# Altium schematic sheet Power Management.SchDoc("Power Management")
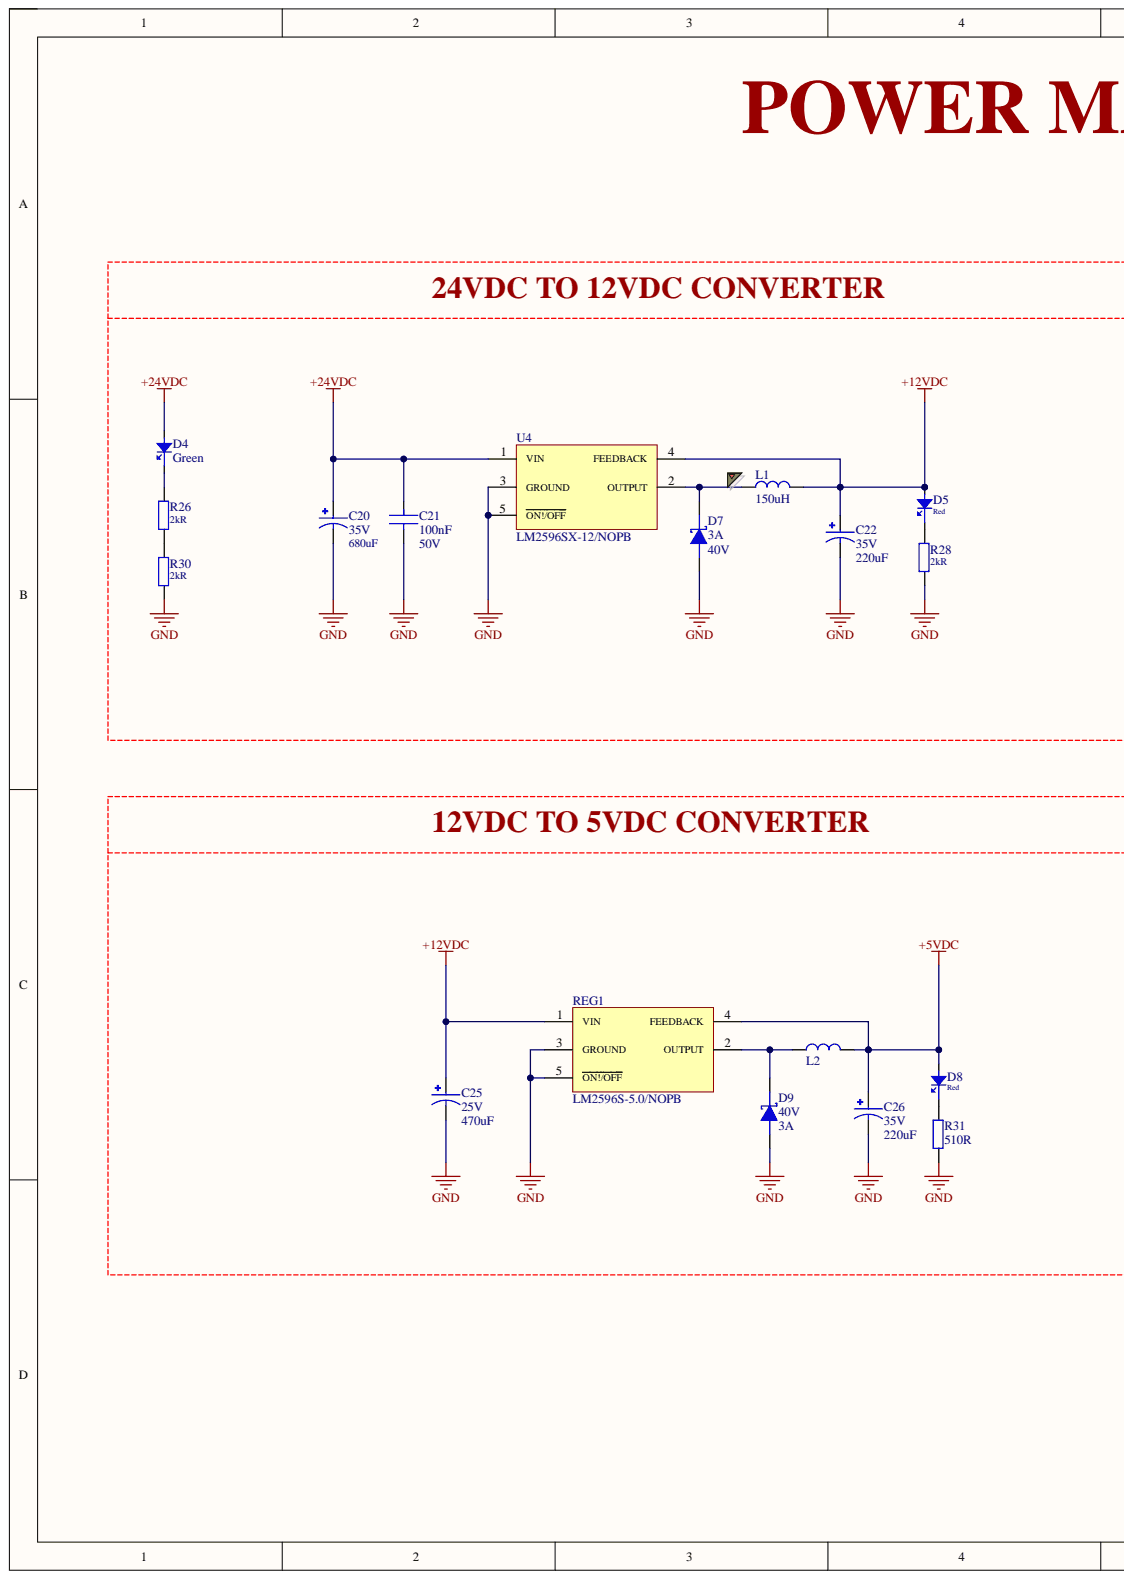
[diagram p0: Power Management.SchDoc, left]
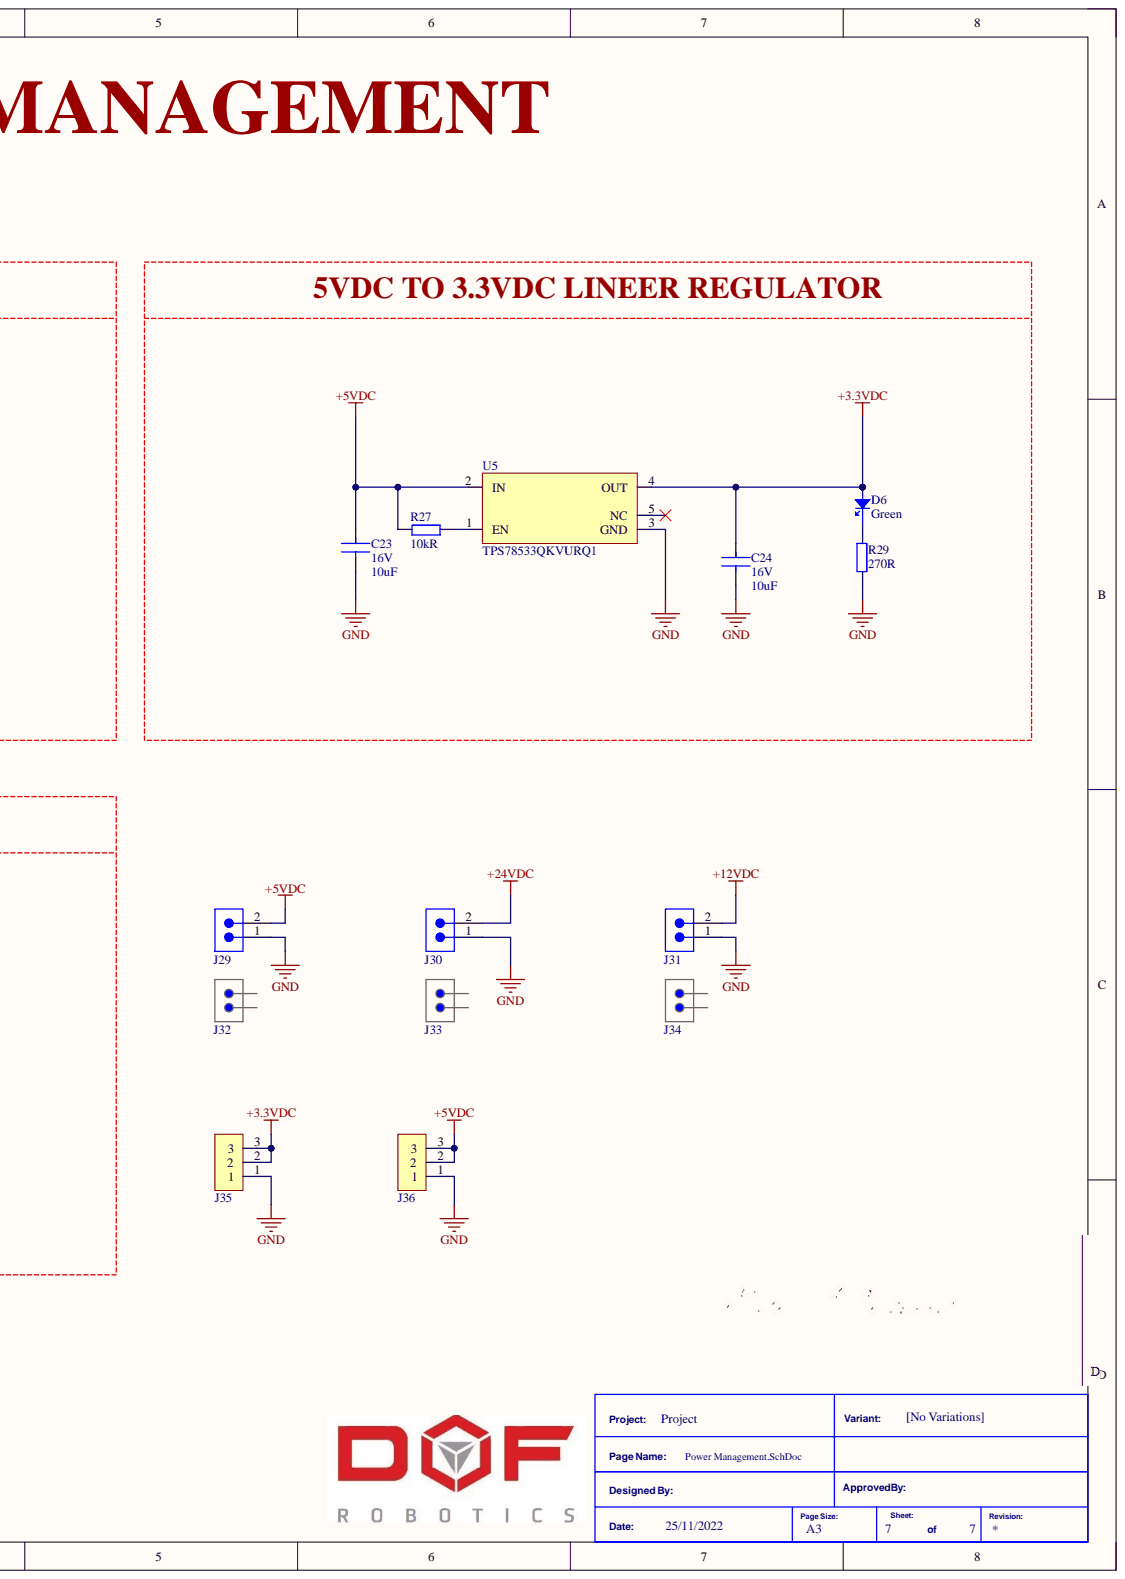
[diagram p1: Power Management.SchDoc, right]

## Parts
C20  Capacitance: 680uF  Voltage: 35V  [CAP ALUM RAD 10MM]  (hidden comment: EEU-FR1V681)
C21  Capacitance: 100nF  Voltage Rating (DC): 50V  [CAP CER 0603]  (hidden comment: C0603C104K5RAC7082)
C22  Capacitance: 220uF  Voltage: 35V  [CAP ALUM SMD 10X10X12]  (hidden comment: MAL214699004E3)
C23  Capacitance: 10uF  Voltage: 16V  [CAP CER 0805]  (hidden comment: C0805C106K4PACTU)
C24  Capacitance: 10uF  Voltage: 16V  [CAP CER 0805]  (hidden comment: C0805C106K4PACTU)
C25  Capacitance: 470uF  Voltage Rating: 25V  [CAP ALUM SMD 8.3X8.3]  (hidden comment: EEEFT1E471GP)
C26  Capacitance: 220uF  Voltage: 35V  [CAP ALUM SMD 10X10X12]  (hidden comment: MAL214699004E3)
D4  Color: Green  [LED GREEN 0805]  (hidden comment: CMD17-21VGD/TR8)
D5  Color: Red  [LED RED 0603]  (hidden comment: 150060RS75000)
D6  Color: Green  [LED GREEN 0805]  (hidden comment: CMD17-21VGD/TR8)
D7  Average Rectified Current: 3A  Max Reverse Voltage (DC): 40V  [DO-214AC]  (hidden comment: ACDBA340-HF)
D8  Color: Red  [LED RED 0603]  (hidden comment: 150060RS75000)
D9  Average Rectified Current: 3A  Max Reverse Voltage (DC): 40V  [DO-214AC]  (hidden comment: ACDBA340-HF)
J29  691313710002  [CONN ML 1X2 WURTH 691313710002]  (value not drawn)
J30  691313710002  [CONN ML 1X2 WURTH 691313710002]  (value not drawn)
J31  691313710002  [CONN ML 1X2 WURTH 691313710002]  (value not drawn)
J32  691352710002  [CONN FML 1X2 WURTH 691352710002]  (value not drawn)
J33  691352710002  [CONN FML 1X2 WURTH 691352710002]  (value not drawn)
J34  691352710002  [CONN FML 1X2 WURTH 691352710002]  (value not drawn)
J35  61300311121  [HDR_ML_1X3]  (value not drawn)
J36  61300311121  [HDR_ML_1X3]  (value not drawn)
L1  Inductance: 150uH  [IND WURTH 12.3X12.3]  (hidden comment: 744771215)
L2  7447706680  [IND WURTH 12.3X12.3]  (value not drawn)
R26  Resistance: 2kR  [RES 0603]  (hidden comment: RC0603FR-072KL)
R27  Resistance: 10kR  [RES 0805]  (hidden comment: RNCP0805FTD10K0)
R28  Resistance: 2kR  [RES 0603]  (hidden comment: RC0603FR-072KL)
R29  Resistance: 270R  [RES 0805]  (hidden comment: CRGCQ0805F270R)
R30  Resistance: 2kR  [RES 0603]  (hidden comment: RC0603FR-072KL)
R31  Resistance: 510R  [RES 0603]  (hidden comment: ESR03EZPJ511)
REG1  LM2596S-5.0/NOPB  [LM2596S-5.0/NOPB]
U4  LM2596SX-12/NOPB  [LM2596S-5.0/NOPB]
U5  TPS78533QKVURQ1  [TO-252-5]

## Nets
+3.3VDC: C24.2 D6.2 J35.2 J35.3 U5.4(OUT)
+5VDC: C23.2 C26.1 D8.2 J29.2 J36.2 J36.3 L2.2 R27.2 REG1.4(FEEDBACK) U5.2(IN)
+12VDC: C22.1 C25.1 D5.2 J31.2 L1.2 REG1.1(VIN) U4.4(FEEDBACK)
+24VDC: C20.1 C21.2 D4.2 J30.2 U4.1(VIN)
GND: C20.2 C21.1 C22.2 C23.1 C24.1 C25.2 C26.2 D7.A D9.A J29.1 J30.1 J31.1 J35.1 J36.1 R28.1 R29.1 R30.1 R31.1 REG1.3(GROUND) REG1.5(ON!/OFF) U4.3(GROUND) U4.5(ON!/OFF) U5.3(GND)
NetD4_1: D4.1 R26.2
NetD5_1: D5.1 R28.2
NetD6_1: D6.1 R29.2
NetD7_C: D7.C L1.1 U4.2(OUTPUT)
NetD8_1: D8.1 R31.2
NetD9_C: D9.C L2.1 REG1.2(OUTPUT)
NetR26_1: R26.1 R30.2
NetR27_1: R27.1 U5.1(EN)

Not connected: U5 5(NC)

## Library data of the parts
C20 = CAP ALUM 680UF 35V RADIAL: CAP ALUM 680UF 20% 35V RADIAL; Manufacturer: Panasonic; ESR (Equivalent Series Resistance): 20mR; Lead Diameter: 0.6mm; Lead/Base Style: Straight; Leakage Current: 3uA; Life (Hours): 10000Hour; Ripple Current: 2.18A; Case/Package: Radial; Category: Aluminum Electrolytic Capacitors; Component Kind: Standard; ComponentLink1Description: https://datasheet.ciiva.com/11780/0900766b80e4e9bf-11780986.pdf?src-supplier=RSComponents; ComponentLink1URL: https://datasheet.ciiva.com/11780/0900766b80e4e9bf-11780986.pdf?src-supplier=RSComponents; ComponentLink2Description: https://datasheet.ciiva.com/11677/aba0000ce132-11677717.pdf?src-supplier=Avnet; ComponentLink2URL: https://datasheet.ciiva.com/11677/aba0000ce132-11677717.pdf?src-supplier=Avnet; ComponentLink3Description: https://datasheet.ciiva.com/14602/70257620-14602587.pdf?src-supplier=Allied+Electronics; ComponentLink3URL: https://datasheet.ciiva.com/14602/70257620-14602587.pdf?src-supplier=Allied+Electronics; ComponentLink4Description: https://datasheet.ciiva.com/30145/9aba0000ce132-30145388.pdf?src-supplier=Onlinecomponents.com; ComponentLink4URL: https://datasheet.ciiva.com/30145/9aba0000ce132-30145388.pdf?src-supplier=Onlinecomponents.com; ComponentLink5Description: https://datasheet.ciiva.com/17531/aba0000ce132-17531901.pdf?src-supplier=Avnet+Europe; ComponentLink5URL: https://datasheet.ciiva.com/17531/aba0000ce132-17531901.pdf?src-supplier=Avnet+Europe; Construction: RADIAL; Contact Plating: Tin; Diameter: 10mm; Height: 20mm; Height - Seated (Max): 0.866inch; Impedance: 20mR; Lead Pitch: 5mm; Length: 20mm; Manufacturer Part Number: EEU-FR1V681; Max Operating Temperature: 105°C; Min Operating Temperature: -40°C; Mount: Through Hole; Number of Pins: 2; Package Quantity: 200; Packaging: Bulk; Pricing 1: 1=1.09000, 10=0.82700, 50=0.68680, 100=0.59140, 500=0.45788, 1000=0.39429, 2500=0.36885, 5000=0.34977 (USD); Radiation Hardening: No; REACH SVHC: Unknown; Ripple Current (AC): 2.18A; RoHS: Yes; RoHS Compliant: Yes; Series: FR; Stock 1: 13043; Supplier 1: Digi-Key; Supplier Part Number 1: P14514-ND; Termination: Radial; Tolerance: 20%; Voltage Rating: 35V; Voltage Rating (DC): 35V; Weight: 2.5; Library Name: LibEnes.SchLib
C21 = CAP CER 0.1UF 50V X7R 0603: Cap Cer 0.1uF 50V 0603 10%; Manufacturer: KEMET; DesignedBy: ENES MERCAN; Dielectric: X7R; Dielectric Material: Ceramic; Case/Package: 0603; Category: Ceramic Capacitors; Component Kind: Standard; Library Name: LibEnes.SchLib; Manufacturer Part Number: C0603C104K5RAC7082; Pricing 1: 1=0.12000, 10=0.08900, 50=0.04840, 100=0.03940, 500=0.02818, 1000=0.02214, 2500=0.02012, 5000=0.01852 (USD); RoHS: Yes; RoHS Compliant: Yes; Stock 1: 44787; Supplier 1: Digi-Key; Supplier Part Number 1: 399-C0603C104K5RAC7082CT-ND; Tolerance: 10%; Weight: 0.063
C22 C26 = CAP ALUM 220UF 35V SMD 1012: 220uF 35V ALUM Electrolytic Cap 1012; 146 CTI Series 1500 h; 2000 h; 200000 h; Manufacturer: Vishay BCcomponents; Impedance: 97mR; Lead Diameter: 1mm; Leakage Current: 77uA; Life (Hours): 2000Hour; Polarity: Polar; Ripple Current: 900mA; Tolerance: 20%; Case/Package: Radial; Category: Aluminum Electrolytic Capacitors; Component Kind: Standard; ComponentLink1Description: https://datasheet.ciiva.com/99/146cti-99688.pdf?src-supplier=Digi-Key; ComponentLink1URL: https://datasheet.ciiva.com/99/146cti-99688.pdf?src-supplier=Digi-Key; ComponentLink2Description: https://datasheet.ciiva.com/99/146cti-99689.pdf?src-supplier=Digi-Key; ComponentLink2URL: https://datasheet.ciiva.com/99/146cti-99689.pdf?src-supplier=Digi-Key; ComponentLink3Description: https://datasheet.ciiva.com/11777/0900766b81003e87-11777120.pdf?src-supplier=RSComponents; ComponentLink3URL: https://datasheet.ciiva.com/11777/0900766b81003e87-11777120.pdf?src-supplier=RSComponents; ComponentLink4Description: https://datasheet.ciiva.com/99/146cti-99690.pdf?src-supplier=Digi-Key; ComponentLink4URL: https://datasheet.ciiva.com/99/146cti-99690.pdf?src-supplier=Digi-Key; ComponentLink5Description: https://datasheet.ciiva.com/5360/146cti-247382-5360191.pdf?src-supplier=Mouser; ComponentLink5URL: https://datasheet.ciiva.com/5360/146cti-247382-5360191.pdf?src-supplier=Mouser; ComponentLink6Description: https://datasheet.ciiva.com/11697/146cti-11697674.pdf?src-supplier=Avnet; ComponentLink6URL: https://datasheet.ciiva.com/11697/146cti-11697674.pdf?src-supplier=Avnet; Construction: Cylindrical; Diameter: 10mm; Height: 12mm; Height - Seated (Max): 0.472inch; Lead Free: Lead Free; Lead Pitch: 3.5mm; Manufacturer Part Number: MAL214699004E3; Max Operating Temperature: 125°C; Min Operating Temperature: -55°C; Mount: Surface Mount; Package Quantity: 250; Packaging: Tape and Reel; Pricing 1: 1=2.65000, 10=2.14700, 50=1.87400, 100=1.63980 (USD); Radiation Hardening: No; RoHS: Yes; RoHS Compliant: Yes; Series: 146 CTI; Stock 1: 4735; Supplier 1: Digi-Key; Supplier Part Number 1: 4427PHCT-ND; Termination: SMD/SMT; Voltage Rating: 35V; Voltage Rating (DC): 35V; Width: 10mm; Library Name: LibEnes.SchLib
C23 C24 = CAP CER 10UF 16V X5R 0805 - C0805C106K4PACTU: CAP CER 10UF 16V X5R 0805; Manufacturer: KEMET; Dielectric Material: Ceramic; Dissipation Factor: 5%; Tolerance: 10%; Case Code (Imperial): 0805; Case Code (Metric): 2012; Case/Package: 0805; Category: Ceramic Capacitors; Component Kind: Standard; ComponentLink1Description: https://datasheet.ciiva.com/7842/1732713-7842196.pdf?src-supplier=Element14; ComponentLink1URL: https://datasheet.ciiva.com/7842/1732713-7842196.pdf?src-supplier=Element14; ComponentLink2Description: https://datasheet.ciiva.com/28682/1831760-28682305.pdf?src-supplier=Element14; ComponentLink2URL: https://datasheet.ciiva.com/28682/1831760-28682305.pdf?src-supplier=Element14; ComponentLink3Description: https://datasheet.ciiva.com/11787/0900766b8116eb59-11787314.pdf?src-supplier=RSComponents; ComponentLink3URL: https://datasheet.ciiva.com/11787/0900766b8116eb59-11787314.pdf?src-supplier=RSComponents; ComponentLink4Description: https://datasheet.ciiva.com/7842/1732713-7842197.pdf?src-supplier=Element14; ComponentLink4URL: https://datasheet.ciiva.com/7842/1732713-7842197.pdf?src-supplier=Element14; ComponentLink5Description: https://datasheet.ciiva.com/26847/getdatasheetpartid-110990-26847815.pdf?src-supplier=Verical; ComponentLink5URL: https://datasheet.ciiva.com/26847/getdatasheetpartid-110990-26847815.pdf?src-supplier=Verical; Construction: Flat; Contact Plating: Tin,Matte; Depth: 1.25mm; Dielectric: X5R; Height: 1.25mm; Lead Free: Lead Free; Length: 2mm; Manufacturer Part Number: C0805C106K4PACTU; Max Operating Temperature: 85°C; Min Operating Temperature: -55°C; Mount: Surface Mount; Number of Pins: 2; Package Quantity: 1; Packaging: Tape and Reel; Pricing 1: 1=0.17000, 10=0.11500, 50=0.06900, 100=0.05830, 500=0.03992, 1000=0.03531 (USD); Radiation Hardening: No; REACH SVHC: No SVHC; RoHS: Yes; RoHS Compliant: Yes; Series: C; Stock 1: 1733841; Supplier 1: Digi-Key; Supplier Part Number 1: 399-C0805C106K4PAC7800CT-ND; Termination: SMD/SMT; Thickness: 0.055inch; Voltage Rating: 16V; Voltage Rating (DC): 16V; Width: 0.049inch; Library Name: LibEnes.SchLib
C25 = CAP ALUM 470UF 25V FT 8X10: Cap Aluminum Lytic 470uF 25V 20% (8 X 10.2mm) SMD 0.08 Ohm 850mA 5000h 105C Automotive T/R; Manufacturer: Panasonic; ESR (Equivalent Series Resistance): 80mR; Life (Hours): 5000Hour; Polarity: Polar; Ripple Current: 552.5mA; Case/Package: Radial; Category: Aluminum Electrolytic Capacitors; Component Kind: Standard; ComponentLink1Description: http://www.farnell.com/datasheets/2813661.pdf?src-supplier=Farnell; ComponentLink1URL: http://www.farnell.com/datasheets/2813661.pdf?src-supplier=Farnell; ComponentLink2Description: http://www.farnell.com/datasheets/2079855.pdf?src-supplier=Element14; ComponentLink2URL: http://www.farnell.com/datasheets/2079855.pdf?src-supplier=Element14; ComponentLink3Description: https://industrial.panasonic.com/cdbs/www-data/pdf/RDE0000/DME0000COL53.pdf?src-supplier=Digi-Key; ComponentLink3URL: https://industrial.panasonic.com/cdbs/www-data/pdf/RDE0000/DME0000COL53.pdf?src-supplier=Digi-Key; ComponentLink4Description: https://industrial.panasonic.com/cdbs/www-data/pdf/RDE0000/DME0000COL41.pdf?src-supplier=Digi-Key; ComponentLink4URL: https://industrial.panasonic.com/cdbs/www-data/pdf/RDE0000/DME0000COL41.pdf?src-supplier=Digi-Key; Diameter: 8mm; Height: 10.2mm; Height - Seated (Max): 0.413inch; Manufacturer Part Number: EEEFT1E471GP; Max Operating Temperature: 105°C; Min Operating Temperature: -55°C; Mount: Surface Mount; Packaging: Cut Tape; Pricing 1: 1=1.33000, 10=1.01300, 50=0.84180, 100=0.72480 (USD); REACH SVHC: No SVHC; Ripple Current (AC): 850mA; RoHS: Yes; RoHS Compliant: Yes; Stock 1: 27228; Supplier 1: Digi-Key; Supplier Part Number 1: PCE5116CT-ND; Tolerance: 20%; Voltage Rating (DC): 25V; Library Name: LibEnes.SchLib
D4 D6 = LED GREEN 0805: LED, Green, SMD 0805, 7.0 mcd, Green Diffused Lens; Manufacturer: VCC CHML; Forward Current: 20mA; Forward Voltage: 2.1V; Lens Style: Rectangular,Diffused,Tinted,Colored; Luminous Intensity: 7mcd; Number of Elements: 1; Power Dissipation: 105mW; Reverse Voltage: 5V; Viewing Angle: 140°; Wavelength: 570nm; Case Code (Imperial): 0805; Case Code (Metric): 2012; Case/Package: 0805; Category: LEDs; Component Kind: Standard; ComponentLink1Description: https://datasheet.ciiva.com/46391/719069-46391062.pdf?src-supplier=Element14; ComponentLink1URL: https://datasheet.ciiva.com/46391/719069-46391062.pdf?src-supplier=Element14; ComponentLink2Description: https://datasheet.ciiva.com/16437/cmd17-21seriessmtleds0805packagesize-16437018.pdf?src-supplier=Future+Electronics; ComponentLink2URL: https://datasheet.ciiva.com/16437/cmd17-21seriessmtleds0805packagesize-16437018.pdf?src-supplier=Future+Electronics; Depth: 1.25mm; Height: 1.1mm; Illumination Color: Green; Lead Free: Lead Free; Length: 2mm; Library Name: My_SCH_Lib.SchLib; Manufacturer Part Number: CMD17-21VGD/TR8; Max Operating Temperature: 85°C; Min Operating Temperature: -40°C; Mount: Surface Mount; Number of LEDs: 1; Number of Pins: 2; Package Quantity: 3000; Packaging: Tape and Reel; Power Rating: 105mW; Pricing 1: 1=0.69000, 10=0.45100, 100=0.29850, 1000=0.23051 (USD); REACH SVHC: Unknown; Reverse Voltage (DC): 5V; RoHS: Yes; Stock 1: 77654; Supplier 1: Digi-Key; Supplier Part Number 1: L62505DKR-ND; Test Current: 20mA; Width: 1.25mm
D5 D8 = LED RED 0603: WURTH ELEKTRONIK - 150060RS75000 - LED, Red, 625 nm, 2 V, 30 mA, 250 mcd RoHS Compliant: Yes; Manufacturer: Wurth Electronics; Dominant Wavelength: 625nm; Forward Current: 30mA; Forward Voltage: 2V; Lens Style: Clear,Rectangular; Luminous Intensity: 250mcd; Test Current: 20mA; Viewing Angle: 140°; Case Code (Imperial): 0603; Case Code (Metric): 1608; Case/Package: 0603; Category: LEDs; Component Kind: Standard; ComponentLink1Description: https://datasheet.ciiva.com/29563/150060rs75000-29563040.pdf?src-supplier=Digi-Key; ComponentLink1URL: https://datasheet.ciiva.com/29563/150060rs75000-29563040.pdf?src-supplier=Digi-Key; ComponentLink2Description: https://datasheet.ciiva.com/29279/759428-da-01-en-chipled-0603-w-kl-rot-150060rs75000-29279196.pdf?src-supplier=Conrad; ComponentLink2URL: https://datasheet.ciiva.com/29279/759428-da-01-en-chipled-0603-w-kl-rot-150060rs75000-29279196.pdf?src-supplier=Conrad; Height: 0.7mm; Length: 1.6mm; Manufacturer Part Number: 150060RS75000; Max Operating Temperature: 85°C; Min Operating Temperature: -40°C; Mount: Surface Mount; Package Quantity: 1; Packaging: Cut Tape; Pricing 1: 1=0.14000, 50=0.13300, 100=0.12000, 500=0.11400, 1000=0.09900 (USD); REACH SVHC: Unknown; RoHS: Yes; RoHS Compliant: Yes; Stock 1: 253591; Supplier 1: Digi-Key; Supplier Part Number 1: 732-4978-1-ND; Wavelength: 625nm; Weight: 0.0025; Width: 0.8mm; Library Name: LibEnes.SchLib
D7 D9 = SCHOTTKY 40V 3A ACDBA340-HF: Rectifier Diode Schottky 40V 3A Automotive 2-Pin SMA T/R; Manufacturer: Comchip; Case/Package: DO-214AC; Component Kind: Standard; ComponentLink1Description: http://www.comchiptech.com/admin/files/product/ACDBA340-HF%20RevA772473.pdf?src-supplier=Digi-Key; ComponentLink1URL: http://www.comchiptech.com/admin/files/product/ACDBA340-HF%20RevA772473.pdf?src-supplier=Digi-Key; Manufacturer Part Number: ACDBA340-HF; Mount: Surface Mount; Packaging: Tape and Reel; Pricing 1: 1=0.44000, 10=0.35700, 100=0.24320, 500=0.18240, 1000=0.13680, 2000=0.12540 (USD); RoHS: Yes; RoHS Compliant: Yes; Stock 1: 128598; Supplier 1: Digi-Key; Supplier Part Number 1: 641-1877-1-ND; Library Name: LibEnes.SchLib
J29-J31 = CONN ML 1X2 WURTH 691313710002: 5mm Pitch Right Angle Pluggable Term Block; PCB Header; Through Hole; 2 Way  (Mate to 691352710002); Manufacturer: Wurth Electronics; Connector Type: PCB,Terminal Block; Current: 20A; Number of Contacts: 2; Number of Levels: 1; Pitch: 0.197inch; Voltage: 300V; Category: Terminal Blocks; Color: Green; Component Kind: Standard; ComponentLink1Description: https://datasheet.ciiva.com/795/6913137100xx-795778.pdf?src-supplier=Digi-Key; ComponentLink1URL: https://datasheet.ciiva.com/795/6913137100xx-795778.pdf?src-supplier=Digi-Key; ComponentLink2Description: https://datasheet.ciiva.com/8043/1442229-8043531.pdf?src-supplier=Element14; ComponentLink2URL: https://datasheet.ciiva.com/8043/1442229-8043531.pdf?src-supplier=Element14; ComponentLink3Description: https://datasheet.ciiva.com/48692/001088691-da-01-en-wr-tbl-terminal-block3137-691313710002-48692902.pdf?src-supplier=Conrad; ComponentLink3URL: https://datasheet.ciiva.com/48692/001088691-da-01-en-wr-tbl-terminal-block3137-691313710002-48692902.pdf?src-supplier=Conrad; Contact Material: Brass; Height: 12mm; Length: 12.5mm; Manufacturer Part Number: 691313710002; Mount: Through Hole; Number of Positions Per Level: 2; Number of Rows: 1; Package Quantity: 600; Packaging: Bulk; Pricing 1: 1=0.44000, 10=0.39400, 50=0.34000, 100=0.30500, 250=0.28600, 600=0.26900 (USD); REACH SVHC: Unknown; RoHS: Yes; RoHS Compliant: Yes; Series: WR-TBL Series 3137; Stock 1: 2898; Supplier 1: Digi-Key; Supplier Part Number 1: 732-2799-ND; Termination: Solder; Width: 12mm; Library Name: LibEnes.SchLib
J32-J34 = CONN FML 1X2 WURTH 691352710002: Conn Terminal Block F 2 POS 5mm Screw RA Cable Mount 20A Box    (Mate to 691313710002); Manufacturer: Wurth Electronics; Current Rating: 12A; Number of Contacts: 2; Number of Levels: 1; Pitch: 0.197inch; Stripping Length: -7mm; Voltage: 300V; Wire Gauge (Max): 24AWG; Wire Gauge (Min): 12AWG; Category: Terminal Blocks; Color: Green; Component Kind: Standard; ComponentLink1Description: https://datasheet.ciiva.com/7992/1442233-7992379.pdf?src-supplier=Element14; ComponentLink1URL: https://datasheet.ciiva.com/7992/1442233-7992379.pdf?src-supplier=Element14; ComponentLink2Description: https://datasheet.ciiva.com/796/6913527100xx-796063.pdf?src-supplier=Digi-Key; ComponentLink2URL: https://datasheet.ciiva.com/796/6913527100xx-796063.pdf?src-supplier=Digi-Key; Contact Material: Brass; Current: 20A; Lead Free: Lead Free; Length: 18.2mm; Manufacturer Part Number: 691352710002; Mount: Free Hanging; Number of Positions Per Level: 2; Package Quantity: 350; Packaging: Bulk; REACH SVHC: Unknown; RoHS: Yes; RoHS Compliant: Yes; Series: WR-TBL Series 3527; Stock 1: 2782; Supplier 1: Digi-Key; Supplier Part Number 1: 732-2775-ND; Supplier Unit Price 1: 1=1.32000, 10=1.18200, 50=1.02200, 100=0.91400, 350=0.85900, 700=0.80500 (USD); Termination: Screw; Voltage Rating: 300V; Width: 10mm; Wire/Cable Gauge: 24AWG; Library Name: LibEnes.SchLib
J35 J36 = HDR ML 1X3 WURTH 61300311121: CONN HEADER 3 POS 2.54; Manufacturer: Wurth Electronics; Connector Type: Male Pin; Gender: Male; Number of Contacts: 3; Pitch: 0.1inch; Category: Connectors; Color: Black; Component Kind: Standard; ComponentLink1Description: http://www.farnell.com/cad/1737268.pdf?src-supplier=Element14; ComponentLink1URL: http://www.farnell.com/cad/1737268.pdf?src-supplier=Element14; ComponentLink2Description: https://katalog.we-online.de/em/datasheet/6130xx11121.pdf?src-supplier=Digi-Key; ComponentLink2URL: https://katalog.we-online.de/em/datasheet/6130xx11121.pdf?src-supplier=Digi-Key; Contact Material: Copper; Contact Mating Length: 0.236inch; Contact Plating: Gold; Lead Free: Lead Free; Manufacturer Part Number: 61300311121; Mount: Through Hole; Number of Positions: 3; Number of Rows: 1; Packaging: Bulk; Pricing 1: 1=0.13000, 10=0.11300, 25=0.09400, 50=0.07900, 100=0.07100, 1000=0.06600 (USD); REACH SVHC: Unknown; RoHS: Yes; RoHS Compliant: Yes; Stock 1: 76429; Supplier 1: Digi-Key; Supplier Part Number 1: 732-5316-ND; Termination: Solder; Library Name: LibEnes.SchLib
L1 = IND 150UH 1.2A 20%: FIXED IND 150UH 1.21A 230MOHM SM  Inductors, Fixed, WE-PD 1260 150uH 1.21A 0.23Ohm; Manufacturer: Wurth Electronics; Core Material: Ferrite; DC Resistance (DCR): 230mR; Inductance Tolerance: 20%; Saturation Current: 1.43A; Self Resonant Frequency: 4.4MHz; Shielding: Shielded; Test Frequency: 1kHz; Case/Package: Nonstandard; Category: Fixed Inductors; Component Kind: Standard; ComponentLink1Description: https://datasheet.ciiva.com/13319/744771215-63141-13319506.pdf?src-supplier=Mouser; ComponentLink1URL: https://datasheet.ciiva.com/13319/744771215-63141-13319506.pdf?src-supplier=Mouser; ComponentLink2Description: https://datasheet.ciiva.com/6696/744771215-6696200.pdf?src-supplier=Digi-Key; ComponentLink2URL: https://datasheet.ciiva.com/6696/744771215-6696200.pdf?src-supplier=Digi-Key; ComponentLink3Description: https://datasheet.ciiva.com/30489/0900766b81281bac-30489276.pdf?src-supplier=RSComponents; ComponentLink3URL: https://datasheet.ciiva.com/30489/0900766b81281bac-30489276.pdf?src-supplier=RSComponents; ComponentLink4Description: https://datasheet.ciiva.com/26307/744771215-eng-tds-26307975.pdf?src-supplier=Distrelec; ComponentLink4URL: https://datasheet.ciiva.com/26307/744771215-eng-tds-26307975.pdf?src-supplier=Distrelec; ComponentLink5Description: https://datasheet.ciiva.com/7892/744771215-7892821.pdf?src-supplier=Element14; ComponentLink5URL: https://datasheet.ciiva.com/7892/744771215-7892821.pdf?src-supplier=Element14; ComponentLink6Description: https://datasheet.ciiva.com/6694/744771215-6694106.pdf?src-supplier=Digi-Key; ComponentLink6URL: https://datasheet.ciiva.com/6694/744771215-6694106.pdf?src-supplier=Digi-Key; Current Rating: 1.21A; Depth: 12mm; Height: 6mm; Height - Seated (Max): 0.236inch; Lead Pitch: 7mm; Length: 12mm; Manufacturer Part Number: 744771215; Max DC Current: 1.21A; Max Operating Temperature: 125°C; Min Operating Temperature: -40°C; Mount: Surface Mount; Package Quantity: 500; Packaging: Tape and Reel; Pricing 1: 1=2.26000, 10=2.17200, 50=1.96100, 100=1.75900, 250=1.61000 (USD); REACH SVHC: Unknown; Resistance: 230mR; Resistor Type: Wirewound; RMS Current (Irms): 1.21A; RoHS: Yes; RoHS Compliant: Yes; Series: WE-PD; Series Resistance: 230mR; Stock 1: 8823; Supplier 1: Digi-Key; Supplier Part Number 1: 732-4023-1-ND; Termination: SMD/SMT; Tolerance: 20%; Width: 12mm; Library Name: LibEnes.SchLib
L2 = IND 68UF 2.3A 20%: Inductor, Aec-Q200, 68Uh, Shld, 2.3A Rohs Compliant: Yes; Manufacturer: Wurth Electronics; Category: Fixed Inductors; Component Kind: Standard; Inductance: 68uH; Manufacturer Part Number: 7447706680; Pricing 1: 1=1.24000, 10=1.11200, 50=1.02200, 80=0.93900 (USD); RoHS: Yes; Stock 1: 4644; Supplier 1: Digi-Key; Supplier Part Number 1: 732-7447706680CT-ND; Library Name: LibEnes.SchLib
R26 R28 R30 = RES 2K 0603: YAGEO - RC0603FR-072KL - RES, THICK FILM, 2K, 1%, 0.1W, 0603; Max Power Dissipation: 100mW; Temperature Coefficient: 100ppm/°C; Voltage Rating: 50V; Working Voltage: 75V; Case Code (Imperial): 0603; Case Code (Metric): 1608; Case/Package: 0603; Category: Chip SMD Resistors; Component Kind: Standard; ComponentLink1Description: https://datasheet.ciiva.com/11808/0900766b80f96399-11808134.pdf?src-supplier=RSComponents; ComponentLink1URL: https://datasheet.ciiva.com/11808/0900766b80f96399-11808134.pdf?src-supplier=RSComponents; ComponentLink2Description: https://datasheet.ciiva.com/22747/443971-22747526.pdf?src-supplier=Element14; ComponentLink2URL: https://datasheet.ciiva.com/22747/443971-22747526.pdf?src-supplier=Element14; ComponentLink3Description: https://datasheet.ciiva.com/2453/pyu-r-int-thick-7-2453913.pdf?src-supplier=Digi-Key; ComponentLink3URL: https://datasheet.ciiva.com/2453/pyu-r-int-thick-7-2453913.pdf?src-supplier=Digi-Key; ComponentLink4Description: https://datasheet.ciiva.com/12555/rc0603-272842-12555257.pdf?src-supplier=Mouser; ComponentLink4URL: https://datasheet.ciiva.com/12555/rc0603-272842-12555257.pdf?src-supplier=Mouser; ComponentLink5Description: https://datasheet.ciiva.com/2453/pyu-r-int-thick-7-2453914.pdf?src-supplier=Digi-Key; ComponentLink5URL: https://datasheet.ciiva.com/2453/pyu-r-int-thick-7-2453914.pdf?src-supplier=Digi-Key; ComponentLink6Description: https://datasheet.ciiva.com/2453/pyu-r-int-thick-7-2453915.pdf?src-supplier=Digi-Key; ComponentLink6URL: https://datasheet.ciiva.com/2453/pyu-r-int-thick-7-2453915.pdf?src-supplier=Digi-Key; Construction: Rectangular; Depth: 0.8mm; Features: Moisture Resistant; Height: 0.45mm; Lead Free: Lead Free; Length: 1.6mm; Manufacturer: Yageo; Manufacturer Part Number: RC0603FR-072KL; Max Operating Temperature: 155°C; Military Standard: Not; Min Operating Temperature: -55°C; Number of Pins: 2; Number of Terminations: 2; Package Quantity: 1; Packaging: Tape and Reel; Power Rating: 100mW; Pricing 1: 1=0.10000, 10=0.02400, 100=0.00970, 1000=0.00436, 2500=0.00378 (USD); REACH SVHC: No SVHC; Resistance Tolerance: 1%; Resistor Type: Thick Film; RoHS: Yes; RoHS Compliant: Yes; Series: RC; Stock 1: 2369590; Supplier 1: Digi-Key; Supplier Part Number 1: 311-2.00KHRCT-ND; Technology: THICK FILM; Termination: SMD/SMT; Tolerance: 1%; Voltage Rating (DC): 50V; Width: 0.031inch; Library Name: LibEnes.SchLib
R27 = RES 10K 0805: Res Thin Film 0805 10K Ohm 1% 1/4W ±100ppm/°C Molded SMD Paper T/R; Manufacturer: Stackpole Electronics; Max Power Dissipation: 250mW; Case Code (Imperial): 0805; Case Code (Metric): 2012; Case/Package: 0805; Category: Chip SMD Resistors; Component Kind: Standard; ComponentLink1Description: https://www.seielect.com/catalog/SEI-Packaging.pdf?src-supplier=Digi-Key; ComponentLink1URL: https://www.seielect.com/catalog/SEI-Packaging.pdf?src-supplier=Digi-Key; Features: Moisture Resistant,Anti-Sulfur; Height: 0.024inch; Lead Free: Lead Free; Length: 0.079inch; Manufacturer Part Number: RNCP0805FTD10K0; Max Operating Temperature: 155°C; Min Operating Temperature: -55°C; Mount: Surface Mount; Number of Terminations: 2; Packaging: Tape and Reel; Pricing 1: 1=0.10000, 10=0.07300, 100=0.02970, 1000=0.01336, 2500=0.01159 (USD); Resistor Type: Thin Film; RoHS: Yes; RoHS Compliant: Yes; Series: RNCP; Stock 1: 1114; Supplier 1: Digi-Key; Supplier Part Number 1: RNCP0805FTD10K0CT-ND; Temperature Coefficient: 100ppm/°C; Tolerance: 1%; Width: 0.049inch; Library Name: LibEnes.SchLib
R29 = RES 270R 1% 0.125W 0805: Res Thick Film 0805 270 Ohm 1% 0.125W(1/8W) ±100ppm/C Pad SMD Automotive T/R; Manufacturer: TE Connectivity; Category: Chip SMD Resistors; Component Kind: Standard; ComponentLink1Description: https://www.te.com/commerce/DocumentDelivery/DDEController?Action=srchrtrv&amp;DocNm=1773204-3&amp;DocType=DS&amp;DocLang=English&src-supplier=Digi-Key; ComponentLink1URL: https://www.te.com/commerce/DocumentDelivery/DDEController?Action=srchrtrv&amp;DocNm=1773204-3&amp;DocType=DS&amp;DocLang=English&src-supplier=Digi-Key; ComponentLink2Description: https://www.te.com/commerce/DocumentDelivery/DDEController?Action=srchrtrv&DocNm=1773204-3&DocType=DS&DocLang=English&src-supplier=Digi-Key; ComponentLink2URL: https://www.te.com/commerce/DocumentDelivery/DDEController?Action=srchrtrv&DocNm=1773204-3&DocType=DS&DocLang=English&src-supplier=Digi-Key; Manufacturer Part Number: CRGCQ0805F270R; Pricing 1: 1=0.10000, 10=0.04800, 100=0.01960, 1000=0.00879, 2500=0.00763 (USD); RoHS: Yes; Stock 1: 130113; Supplier 1: Digi-Key; Supplier Part Number 1: A129742CT-ND; Library Name: LibEnes.SchLib
R31 = RES 510R 5% 0.25W 0603: Res Thick Film 0603 510 Ohm 5% 1/4W +/-200ppm/ßC Molded SMD SMD Paper T/R; Manufacturer: Rohm; Max Power Dissipation: 250mW; Temperature Coefficient: 200ppm/°C; Case Code (Imperial): 0603; Case Code (Metric): 1608; Case/Package: 0603; Category: Chip SMD Resistors; Component Kind: Standard; ComponentLink1Description: http://www.rohm.com/web/global/search/parametric/-/search/generateQRCode/dsheet/22/1/40101045/ESR10EZPD?src-supplier=Avnet; ComponentLink1URL: http://www.rohm.com/web/global/search/parametric/-/search/generateQRCode/dsheet/22/1/40101045/ESR10EZPD?src-supplier=Avnet; ComponentLink2Description: https://www.rohm.com/datasheet/ESR01MZPF/esr-e?src-supplier=Digi-Key; ComponentLink2URL: https://www.rohm.com/datasheet/ESR01MZPF/esr-e?src-supplier=Digi-Key; Contact Plating: Tin,Matte; Features: Automotive AEC-Q200; Lead Free: Lead Free; Manufacturer Part Number: ESR03EZPJ511; Max Operating Temperature: 155°C; Min Operating Temperature: -55°C; Number of Terminations: 2; Packaging: Tape and Reel; Pricing 1: 1=0.13000, 10=0.12100, 100=0.04640, 1000=0.02039, 2500=0.01761 (USD); Radiation Hardening: No; Resistor Type: Thick Film; RoHS: Yes; RoHS Compliant: Yes; Series: ESR; Stock 1: 144888; Supplier 1: Digi-Key; Supplier Part Number 1: RHM510DDKR-ND; Tolerance: 5%; Library Name: LibEnes.SchLib
REG1 = REG LM2596S-5.0/NOPB: Conv DC-DC 4.5V to 40V Inv/Step Down Single-Out 5V 3A 6-Pin(5+Tab) TO-263 Tube; Manufacturer: TI National Semiconductor; Efficiency: 80%; Number of Outputs: 1; Output Type: Fixed; Quiescent Current: 5mA; Switching Frequency: 173kHz; Case/Package: TO-263; Category: Power Supply Modules; Component Kind: Standard; ComponentLink1Description: https://datasheet.ciiva.com/15112/getdatasheetpartid-112894-15112928.pdf?src-supplier=Verical; ComponentLink1URL: https://datasheet.ciiva.com/15112/getdatasheetpartid-112894-15112928.pdf?src-supplier=Verical; ComponentLink2Description: https://datasheet.ciiva.com/14150/jqlm2596x-xx-e-14150651.pdf?src-supplier=Distrelec; ComponentLink2URL: https://datasheet.ciiva.com/14150/jqlm2596x-xx-e-14150651.pdf?src-supplier=Distrelec; ComponentLink3Description: https://datasheet.ciiva.com/27050/836385-27050287.pdf?src-supplier=Jameco; ComponentLink3URL: https://datasheet.ciiva.com/27050/836385-27050287.pdf?src-supplier=Jameco; ComponentLink4Description: https://datasheet.ciiva.com/41457/001013813-da-01-en-ic-reg-buc-lm2596s-5-0-nopb-to-263-5-tid-41457757.pdf?src-supplier=Conrad; ComponentLink4URL: https://datasheet.ciiva.com/41457/001013813-da-01-en-ic-reg-buc-lm2596s-5-0-nopb-to-263-5-tid-41457757.pdf?src-supplier=Conrad; ComponentLink5Description: https://datasheet.ciiva.com/6596/lm2596-6596343.pdf?src-supplier=Digi-Key; ComponentLink5URL: https://datasheet.ciiva.com/6596/lm2596-6596343.pdf?src-supplier=Digi-Key; ComponentLink6Description: https://datasheet.ciiva.com/22669/1874590-22669463.pdf?src-supplier=Element14; ComponentLink6URL: https://datasheet.ciiva.com/22669/1874590-22669463.pdf?src-supplier=Element14; ComponentLink7Description: https://datasheet.ciiva.com/16536/lm2596-16536124.pdf?src-supplier=Avnet+Asia; ComponentLink7URL: https://datasheet.ciiva.com/16536/lm2596-16536124.pdf?src-supplier=Avnet+Asia; Contact Plating: Tin,Matte; Height: 4.57mm; Lead Free: Contains Lead; Length: 10.16mm; Manufacturer Part Number: LM2596S-5.0/NOPB; Max Input Voltage: 40V; Max Operating Temperature: 125°C; Max Output Current: 3A; Min Input Voltage: 4.5V; Min Operating Temperature: -40°C; Mount: Surface Mount; Nominal Output Voltage: 5V; Number of Pins: 5; Operating Supply Voltage: 40V; Output Current: 3A; Output Voltage: 5V; Package Quantity: 45; Packaging: Rail/Tube; Pricing 1: 1=7.15000, 10=6.46000, 50=6.16000, 100=5.34000, 1000=5.34000, 10000=5.34000 (USD); Radiation Hardening: No; REACH SVHC: No SVHC; RoHS: Yes; Stock 1: 3519; Supplier 1: Mouser; Supplier Part Number 1: 926-LM2596S-5.0/NOPB; Weight: 0.25lb; Width: 8.64mm; Library Name: LibEnes.SchLib
U4 = REG LM2596SX-12/NOPB: SIMPLE SWITCHER® 4.5V to 40V, 3A Low Component Count Step-Down Regulator 5-DDPAK/TO-263; Manufacturer: Texas Instruments; Efficiency: 90%; Min Output Voltage: 12V; Number of Outputs: 1; Output Configuration: Positive; Output Type: Fixed; Quiescent Current: 5mA; Switching Frequency: 173kHz; Synchronous Rectifier: No; Case/Package: TO-263; Category: Voltage Regulators - Switching; Component Kind: Standard; ComponentLink1Description: https://datasheet.ciiva.com/15112/getdatasheetpartid-55558-15112635.pdf?src-supplier=Verical; ComponentLink1URL: https://datasheet.ciiva.com/15112/getdatasheetpartid-55558-15112635.pdf?src-supplier=Verical; ComponentLink2Description: https://datasheet.ciiva.com/41457/001013811-da-01-en-ic-reg-buc-lm2596sx-12-nopb-to-263-5-tid-41457755.pdf?src-supplier=Conrad; ComponentLink2URL: https://datasheet.ciiva.com/41457/001013811-da-01-en-ic-reg-buc-lm2596sx-12-nopb-to-263-5-tid-41457755.pdf?src-supplier=Conrad; ComponentLink3Description: https://datasheet.ciiva.com/14303/lm2596-14303583.pdf?src-supplier=Avnet; ComponentLink3URL: https://datasheet.ciiva.com/14303/lm2596-14303583.pdf?src-supplier=Avnet; ComponentLink4Description: https://datasheet.ciiva.com/3220/lm2596-3220759.pdf?src-supplier=Avnet; ComponentLink4URL: https://datasheet.ciiva.com/3220/lm2596-3220759.pdf?src-supplier=Avnet; ComponentLink5Description: https://datasheet.ciiva.com/6603/lm2596-6603274.pdf?src-supplier=Digi-Key; ComponentLink5URL: https://datasheet.ciiva.com/6603/lm2596-6603274.pdf?src-supplier=Digi-Key; ComponentLink6Description: https://datasheet.ciiva.com/6603/lm2596-6603275.pdf?src-supplier=Digi-Key; ComponentLink6URL: https://datasheet.ciiva.com/6603/lm2596-6603275.pdf?src-supplier=Digi-Key; ComponentLink7Description: https://datasheet.ciiva.com/6603/lm2596-6603272.pdf?src-supplier=Digi-Key; ComponentLink7URL: https://datasheet.ciiva.com/6603/lm2596-6603272.pdf?src-supplier=Digi-Key; ComponentLink8Description: https://octopart.com/opatz8j6/c1?t=8fb9YYa9VaqL_PGSXtinXREGww53k4oWrrs6JUF5KPOayGTd4mHqquIDIiJNjwxI9shtUiX4jXGJHL6q2tIz3nNSSD_BqV7oxFOutemJHVn5umq3tl1XgSFrZYOJ67koOEkMJor7dsewDCIhGKLminDhiU0Lyav09YkKEqLZkH5hxfRNvf3qY5fH3R-RX86I9GpHaJaNGLiR8ozoMx-uAMXgbGWC8ASWWwkoBE816lVRjv2W21UsSkce_QXJ_YzbTNyC3sl0BajlqB8n1DLrpqQyF2KT_Iwxzo9ib8UBNy6iGK1wDUSV2hnUFR9s2cPp6Qrlhnrwd6-b1Pet8dt9X-g2t5uqrhQljdsVDRVg2hYfbV1k0L5eQxs_sT23qi9L05kezw&src-supplier=Texas+Instruments; ComponentLink8URL: https://octopart.com/opatz8j6/c1?t=8fb9YYa9VaqL_PGSXtinXREGww53k4oWrrs6JUF5KPOayGTd4mHqquIDIiJNjwxI9shtUiX4jXGJHL6q2tIz3nNSSD_BqV7oxFOutemJHVn5umq3tl1XgSFrZYOJ67koOEkMJor7dsewDCIhGKLminDhiU0Lyav09YkKEqLZkH5hxfRNvf3qY5fH3R-RX86I9GpHaJaNGLiR8ozoMx-uAMXgbGWC8ASWWwkoBE816lVRjv2W21UsSkce_QXJ_YzbTNyC3sl0BajlqB8n1DLrpqQyF2KT_Iwxzo9ib8UBNy6iGK1wDUSV2hnUFR9s2cPp6Qrlhnrwd6-b1Pet8dt9X-g2t5uqrhQljdsVDRVg2hYfbV1k0L5eQxs_sT23qi9L05kezw&src-supplier=Texas+Instruments; ComponentLink9Description: https://datasheet.octopart.com/LM2596SX-12-NOPB-Texas-Instruments-datasheet-14775467.pdf?src-supplier=Texas+Instruments; ComponentLink9URL: https://datasheet.octopart.com/LM2596SX-12-NOPB-Texas-Instruments-datasheet-14775467.pdf?src-supplier=Texas+Instruments; ComponentLink10Description: https://sigma.octopart.com/49250911/technical_drawing/Texas-Instruments-LM2596SX-12-NOPB.pdf?src-supplier=Texas+Instruments; ComponentLink10URL: https://sigma.octopart.com/49250911/technical_drawing/Texas-Instruments-LM2596SX-12-NOPB.pdf?src-supplier=Texas+Instruments; ComponentLink11Description: https://datasheet.octopart.com/LM2596SX-12-NOPB-Texas-Instruments-datasheet-34438175.pdf?src-supplier=Newark; ComponentLink11URL: https://datasheet.octopart.com/LM2596SX-12-NOPB-Texas-Instruments-datasheet-34438175.pdf?src-supplier=Newark; ComponentLink12Description: https://datasheet.octopart.com/LM2596SX-12-NOPB-Texas-Instruments-datasheet-17726397.pdf?src-supplier=Newark; ComponentLink12URL: https://datasheet.octopart.com/LM2596SX-12-NOPB-Texas-Instruments-datasheet-17726397.pdf?src-supplier=Newark; ComponentLink13Description: https://datasheet.octopart.com/LM2596SX-12-NOPB-Texas-Instruments-datasheet-21076667.pdf?src-supplier=Newark; ComponentLink13URL: https://datasheet.octopart.com/LM2596SX-12-NOPB-Texas-Instruments-datasheet-21076667.pdf?src-supplier=Newark; ComponentLink14Description: https://datasheet.octopart.com/LM2596SX-12/NOPB-Texas-Instruments-datasheet-10621667.pdf?src-supplier=Verical; ComponentLink14URL: https://datasheet.octopart.com/LM2596SX-12/NOPB-Texas-Instruments-datasheet-10621667.pdf?src-supplier=Verical; ComponentLink15Description: https://datasheet.octopart.com/LM2596SX-12/NOPB-National-Semiconductor-datasheet-6038.pdf?src-supplier=Verical; ComponentLink15URL: https://datasheet.octopart.com/LM2596SX-12/NOPB-National-Semiconductor-datasheet-6038.pdf?src-supplier=Verical; ComponentLink16Description: https://datasheet.octopart.com/LM2596SX-12-NOPB-Texas-Instruments-datasheet-159115122.pdf?src-supplier=LCSC; ComponentLink16URL: https://datasheet.octopart.com/LM2596SX-12-NOPB-Texas-Instruments-datasheet-159115122.pdf?src-supplier=LCSC; ComponentLink17Description: https://datasheet.octopart.com/LM2596SX-12-NOPB-Texas-Instruments-datasheet-65712979.pdf?src-supplier=Element14; ComponentLink17URL: https://datasheet.octopart.com/LM2596SX-12-NOPB-Texas-Instruments-datasheet-65712979.pdf?src-supplier=Element14; ComponentLink18Description: https://datasheet.octopart.com/LM2596SX-12-NOPB-Texas-Instruments-datasheet-8818371.pdf?src-supplier=Jameco; ComponentLink18URL: https://datasheet.octopart.com/LM2596SX-12-NOPB-Texas-Instruments-datasheet-8818371.pdf?src-supplier=Jameco; Lead Free: Contains Lead; Manufacturer Part Number: LM2596SX-12/NOPB; Max Input Voltage: 40V; Max Operating Temperature: 125°C; Max Output Current: 3A; Min Input Voltage: 4.5V; Min Operating Temperature: -40°C; Min Supply Voltage: 4.5V; Mount: Surface Mount; Nominal Output Voltage: 12V; Number of Pins: 5; Operating Supply Voltage: 40V; Output Current: 3A; Output Voltage: 12V; Package Quantity: 500; Packaging: Tape and Reel; Radiation Hardening: No; REACH SVHC: No SVHC; RoHS Compliant: Yes; Series: SIMPLE SWITCHER®; Supplier 1: Digi-Key; Supplier Part Number 1: LM2596SX-12-ND; Library Name: LibEnes.SchLib
U5 = REG TPS78533QKVURQ1: Automotive, 1-A, high-accuracy, adjustable low-dropout (LDO) linear regulator 5-TO-252 -40 to 150; Manufacturer: Texas Instruments; Case/Package: TO-252; Component Kind: Standard; Manufacturer Part Number: TPS78533QKVURQ1; Mount: Surface Mount; Pricing 1: 1=1.86000, 10=1.66900, 25=1.57400, 100=1.34110, 250=1.25928, 500=1.10188, 1000=0.95040 (USD); RoHS: No; Stock 1: 4865; Supplier 1: Digi-Key; Supplier Part Number 1: 296-TPS78533QKVURQ1CT-ND; Library Name: LibEnes.SchLib
